# Altium schematic sheet LED Block.SchDoc("LED Block")
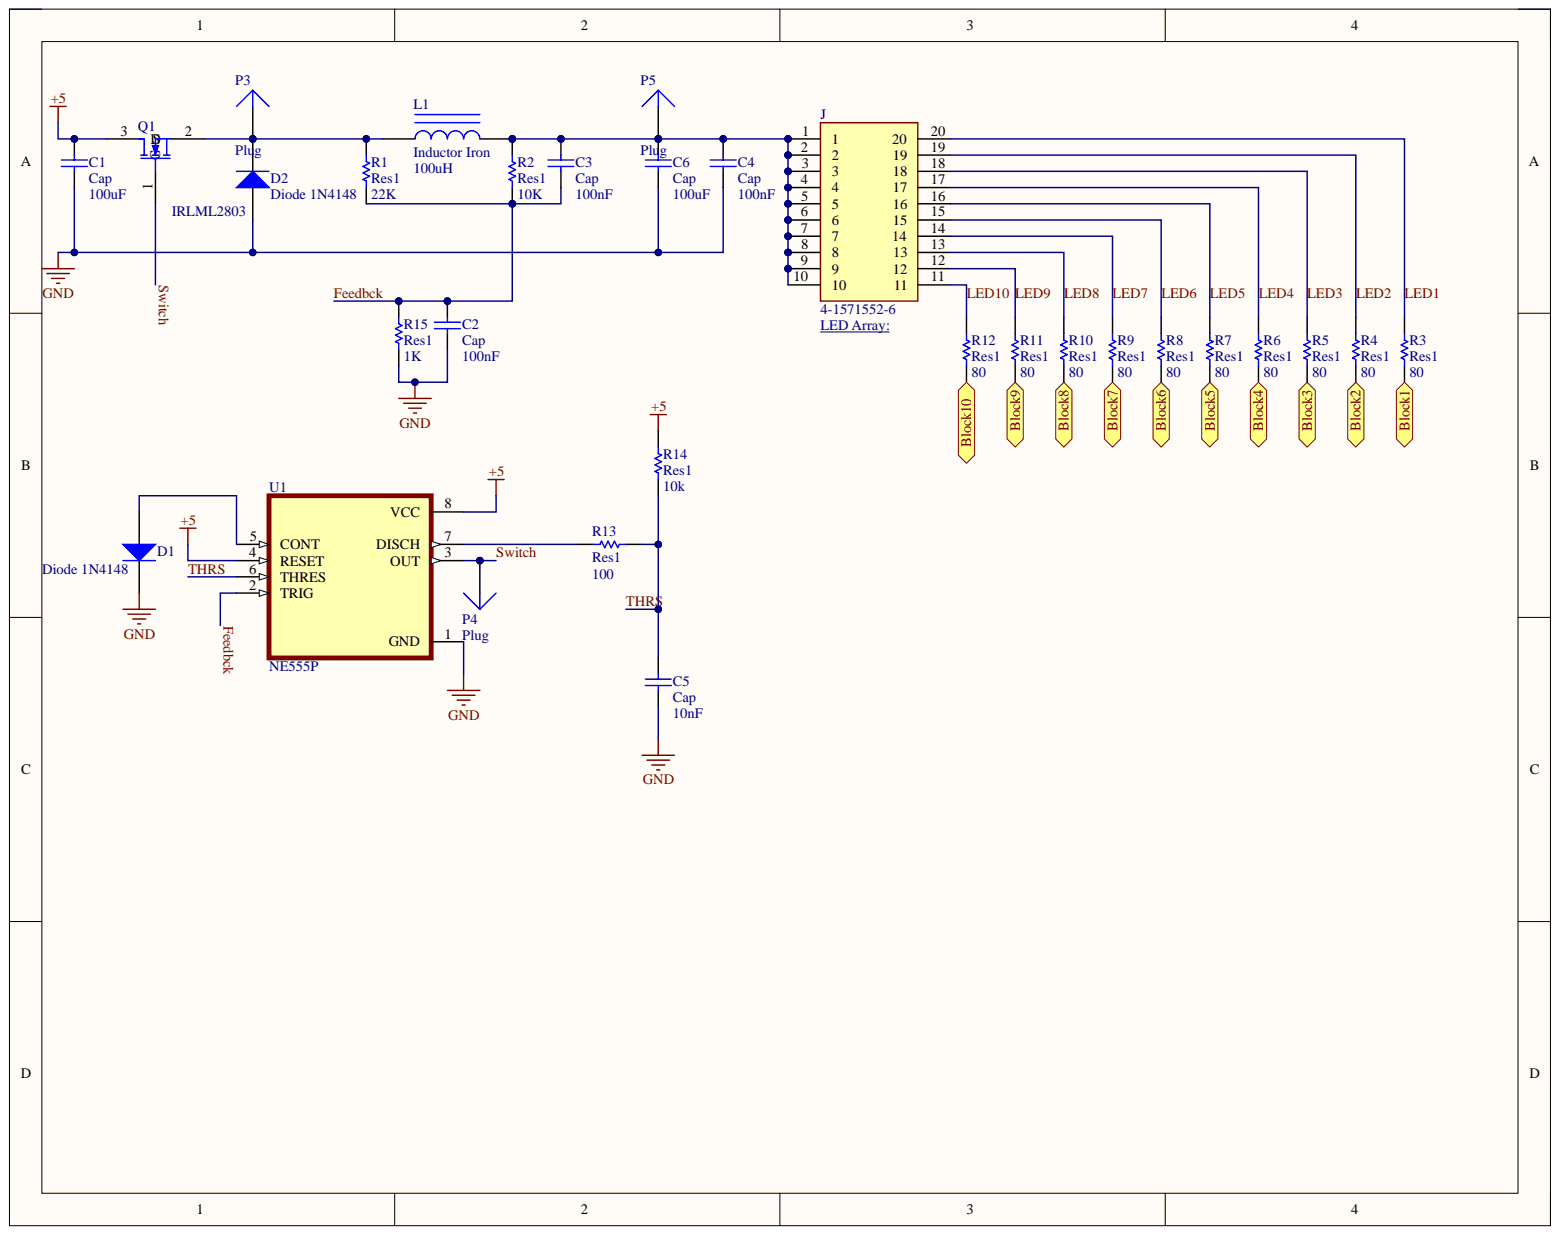
[diagram p0: LED Block.SchDoc]

## Parts
C1  Cap  value 100uF  [CAPR5-4X5]
C2  Cap  value 100nF  [CAPR5-4X5]
C3  Cap  value 100nF  [CAPR5-4X5]
C4  Cap  value 100nF  [CAPR5-4X5]
C5  Cap  value 10nF  [CAPR5-4X5]
C6  Cap  value 100uF  [CAPR5-4X5]
D1  Diode 1N4148  [DO-35]
D2  Diode 1N4148  [DO-35]
J  4-1571552-6  [TE_4-1571552-6]
L1  Inductor Iron  value 100uH  [AXIAL-0.9]
P3  Plug  [PIN1]
P4  Plug  [PIN1]
P5  Plug  [PIN1]
Q1  IRLML2803  [LT-T-3]
R1  Res1  value 22K  [AXIAL-0.3]
R2  Res1  value 10K  [AXIAL-0.3]
R3  Res1  value 80  [AXIAL-0.3]
R4  Res1  value 80  [AXIAL-0.3]
R5  Res1  value 80  [AXIAL-0.3]
R6  Res1  value 80  [AXIAL-0.3]
R7  Res1  value 80  [AXIAL-0.3]
R8  Res1  value 80  [AXIAL-0.3]
R9  Res1  value 80  [AXIAL-0.3]
R10  Res1  value 80  [AXIAL-0.3]
R11  Res1  value 80  [AXIAL-0.3]
R12  Res1  value 80  [AXIAL-0.3]
R13  Res1  value 100  [AXIAL-0.3]
R14  Res1  value 10k  [AXIAL-0.3]
R15  Res1  value 1K  [AXIAL-0.3]
U1  NE555P  [DIP794W45P254L959H508Q8]

## Ports
Block1, Block2, Block3, Block4, Block5, Block6, Block7, Block8, Block9, Block10

## Nets
+5: C1.2 Q1.3 R14.2 U1.4(RESET) U1.8(VCC)
GND: C1.1 C2.1 C4.1 C5.1 C6.1 D1.2 D2.1 R15.1 U1.1(GND)
Block1: R3.1 ; port Block1
Block2: R4.1 ; port Block2
Block3: R5.1 ; port Block3
Block4: R6.1 ; port Block4
Block5: R7.1 ; port Block5
Block6: R8.1 ; port Block6
Block7: R9.1 ; port Block7
Block8: R10.1 ; port Block8
Block9: R11.1 ; port Block9
Block10: R12.1 ; port Block10
Feedbck: C2.2 C3.1 R1.1 R2.1 R15.2 U1.2(TRIG)
LED1: J.20 R3.2
LED2: J.19 R4.2
LED3: J.18 R5.2
LED4: J.17 R6.2
LED5: J.16 R7.2
LED6: J.15 R8.2
LED7: J.14 R9.2
LED8: J.13 R10.2
LED9: J.12 R11.2
LED10: J.11 R12.2
Switch: P4.1 Q1.1 U1.3(OUT)
THRS: C5.2 R13.2 R14.1 U1.6(THRES)
NetC3_2: C3.2 C4.2 C6.2 J.1 J.2 J.3 J.4 J.5 J.6 J.7 J.8 J.9 J.10 L1.2 P5.1 R2.2
NetD1_1: D1.1 U1.5(CONT)
NetD2_2: D2.2 L1.1 P3.1 Q1.2 R1.2
NetR13_1: R13.1 U1.7(DISCH)

## Library data of the parts
C1-C6 = Cap: Capacitor; Component Kind: Standard; LatestRevisionDate: 17-Jul-2002; LatestRevisionNote: Re-released for DXP Platform.; Library Name: Miscellaneous Devices.IntLib; PackageDescription: Radial Cap, Thru-Hole; 2 Leads; 0.3 in Pin Spacing; PackageReference: RAD-0.3; Published: 8-Jun-2000; Signal Integrity: Cap; Simulation: CAP; Publisher: Altium Limited
D1 D2 = Diode 1N4148: High Conductance Fast Diode; Code_JEDEC: DO-204-AH; Component Kind: Standard; ComponentLink1Description: Manufacturer Link; ComponentLink1URL: http://www.fairchildsemi.com/; ComponentLink2Description: Datasheet; ComponentLink2URL: http://www.fairchildsemi.com/ds/1N%2F1N4148.pdf; DatasheetVersion: 1997; LatestRevisionDate: 17-Jul-2002; LatestRevisionNote: Re-released for DXP Platform.; Library Name: Miscellaneous Devices.IntLib; PackageDescription: Diode, Axial; Body 3.8 x 1.9 mm (LxDia. max), Lead Dia. 0.53 mm (max); PackageReference: DO-35; Published: 8-Jun-2000; Signal Integrity: 1N4148; Simulation: 1N4148; Publisher: Altium Limited
J = 4-1571552-6: 20 (2 x 10) Pos DIP, 0.3 (7.62mm) Row Spacing Socket Gold Through Hole; MF: TE Connectivity; Availability: Unavailable; Component Kind: Standard; Library Name: 4-1571552-6.IntLib; MP: 4-1571552-6; Package: SMD-6 Omron Electronics; Price: None; SnapEDA_Link: https://www.snapeda.com/parts/4-1571552-6/TE%20Connectivity%20AMP%20Connectors/view-part/444871/?ref=snap
L1 = Inductor Iron: Magnetic-Core Inductor; Component Kind: Standard; LatestRevisionDate: 17-Jul-2002; LatestRevisionNote: Re-released for DXP Platform.; Library Name: Miscellaneous Devices.IntLib; PackageDescription: Axial Device, Thru-Hole; 2 Leads; 0.9 in Pin Spacing; PackageReference: AXIAL-0.9; Published: 24-Mar-1999; Signal Integrity: Inductor Iron; Simulation: INDUCTOR; Publisher: Altium Limited
Q1 = MOSFET-N: N-Channel MOSFET; Component Kind: Standard; Library Name: ECE LIB new.SCHLIB; Published: 8-Jun-2000; Revision: July-2002: Re-released for DXP Platform.; Simulation: NMOS; Publisher: Altium Limited
R1-R15 = Res1: Resistor; Component Kind: Standard; LatestRevisionDate: 17-Jul-2002; LatestRevisionNote: Re-released for DXP Platform.; Library Name: Miscellaneous Devices.IntLib; PackageDescription: Axial Device, Thru-Hole; 2 Leads; 0.3 in Pin Spacing; PackageReference: AXIAL-0.3; Published: 8-Jun-2000; Signal Integrity: Res; Simulation: RESISTOR; Publisher: Altium Limited
P3-P5 = Plug: Component Kind: Standard; Description: Plug; LatestRevisionDate: 17-Jul-2002; LatestRevisionNote: Re-released for DXP Platform.; Library Name: Miscellaneous Connectors.IntLib; Signal Integrity: Connector; Publisher: Altium Limited
U1 = NE555P: Availability: Unavailable; Component Kind: Standard; Library Name: NE555P.IntLib; MP: NE555P; Package: None; Price: None
